# Altium schematic sheet TC-DK.SchDoc(TC-DK)
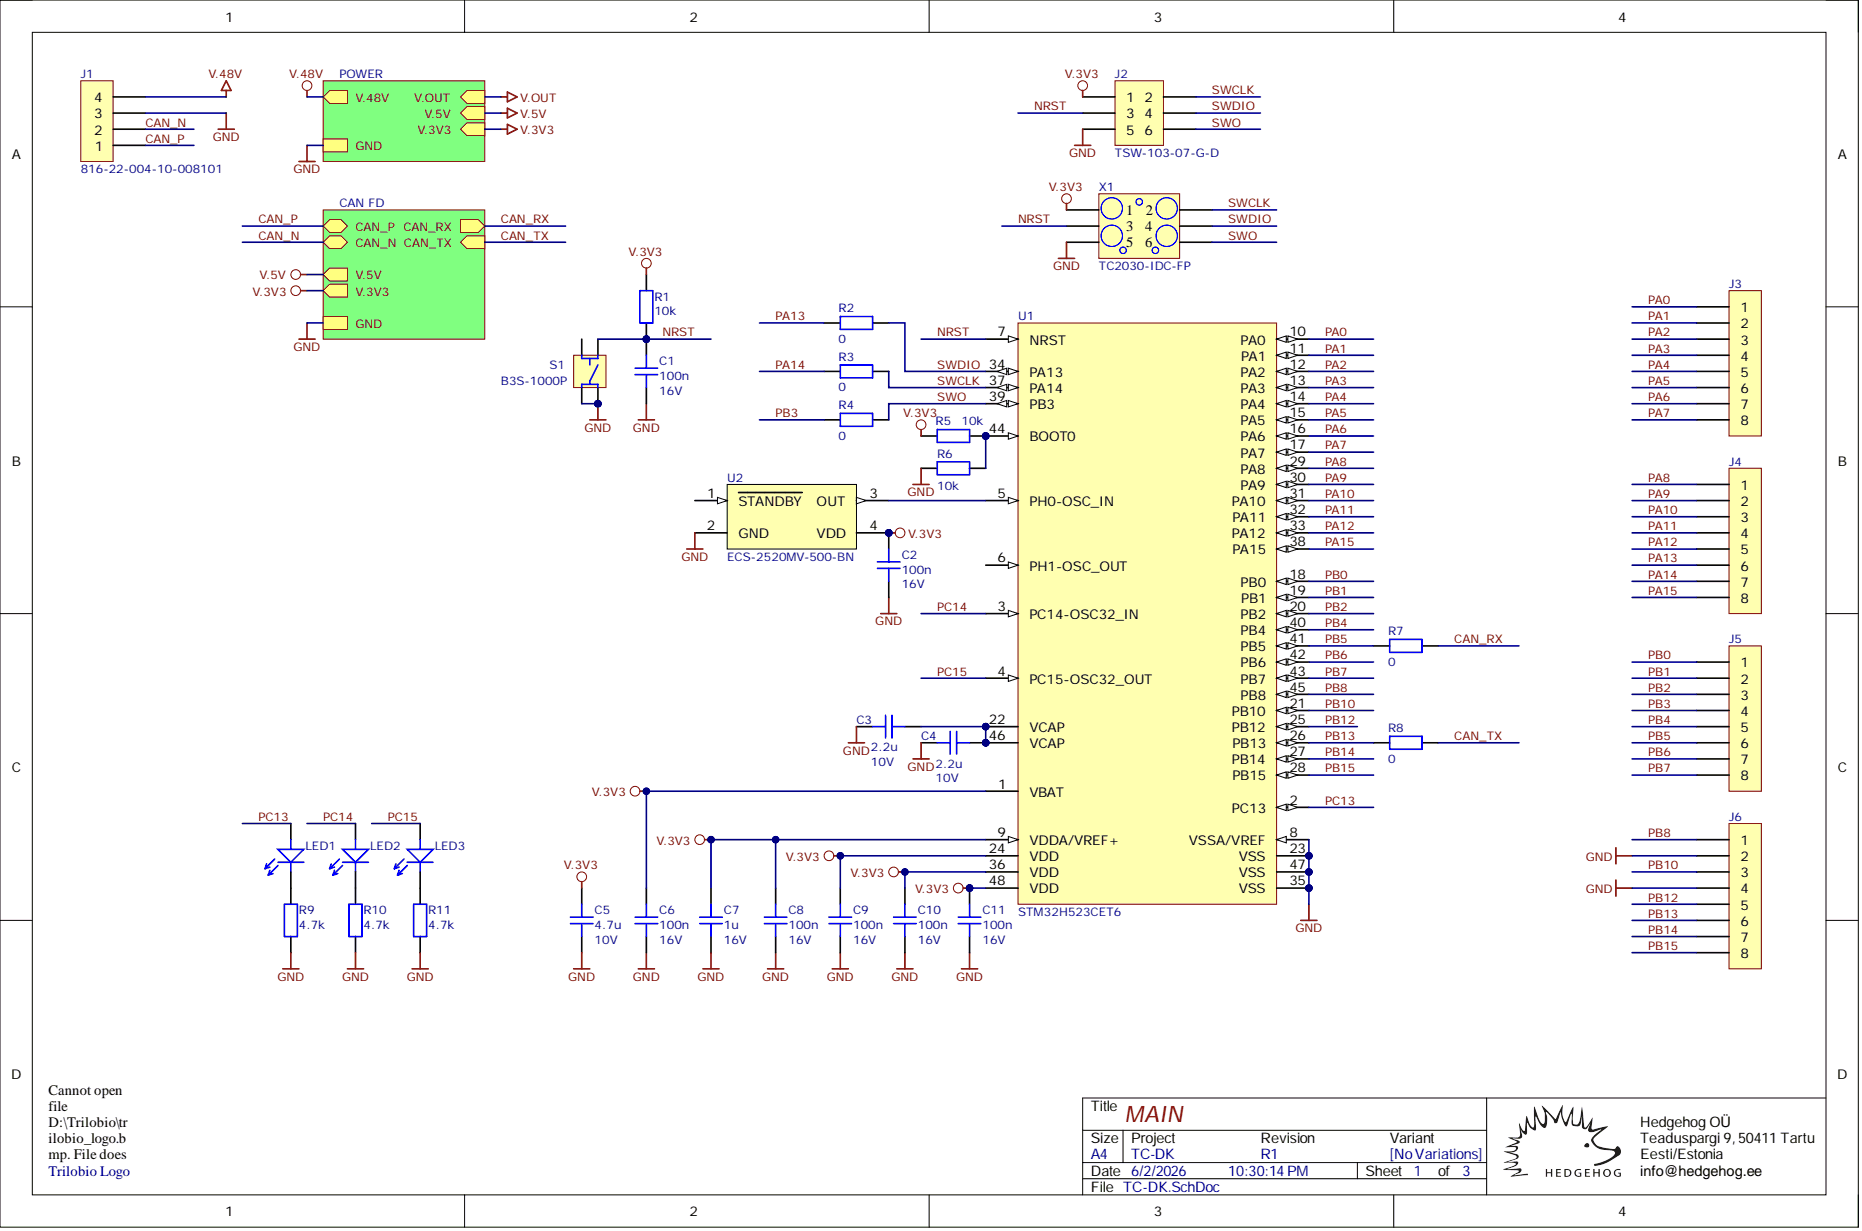
[diagram p0: TC-DK.SchDoc]

## Parts
C1  value 100n  Voltage: 16V  (hidden comment: CAPC)
C2  value 100n  Voltage: 16V  (hidden comment: CAPC)
C3  value 2.2u  Voltage: 10V  (hidden comment: CAPC)
C4  value 2.2u  Voltage: 10V  (hidden comment: CAPC)
C5  value 4.7u  Voltage: 10V  (hidden comment: CAPC)
C6  value 100n  Voltage: 16V  (hidden comment: CAPC)
C7  value 1u  Voltage: 16V  (hidden comment: CAPC)
C8  value 100n  Voltage: 16V  (hidden comment: CAPC)
C9  value 100n  Voltage: 16V  (hidden comment: CAPC)
C10  value 100n  Voltage: 16V  (hidden comment: CAPC)
C11  value 100n  Voltage: 16V  (hidden comment: CAPC)
J1  Part Number: 816-22-004-10-008101  (hidden comment: HDR1x4)
J2  Part Number: TSW-103-07-G-D  (hidden comment: HDR2x3)
J3  HDR1x8  (value not drawn)
J4  HDR1x8  (value not drawn)
J5  HDR1x8  (value not drawn)
J6  HDR1x8  (value not drawn)
LED1  DIO-LED  (value not drawn)
LED2  DIO-LED  (value not drawn)
LED3  DIO-LED  (value not drawn)
Logo1  Trilobio Logo  (no BOM)
R1  value 10k  (hidden comment: RESC)
R2  value 0  (hidden comment: RESC)
R3  value 0  (hidden comment: RESC)
R4  value 0  (hidden comment: RESC)
R5  value 10k  (hidden comment: RESC)
R6  value 10k  (hidden comment: RESC)
R7  value 0  (hidden comment: RESC)
R8  value 0  (hidden comment: RESC)
R9  value 4.7k  (hidden comment: RESC)
R10  value 4.7k  (hidden comment: RESC)
R11  value 4.7k  (hidden comment: RESC)
S1  Part Number: B3S-1000P  (hidden comment: SW_4PIN)
U1  STM32H523CET6
U2  Part Number: ECS-2520MV-500-BN  (hidden comment: XTAL2)
X1  Part Number: TC2030-IDC-FP  (hidden comment: TC2030-FP)  (no BOM)

## Nets
GND: C1.1 C2.1 C3.1 C4.1 C5.1 C6.1 C7.1 C8.1 C9.1 C10.1 C11.1 J1.3 J2.5 J6.2 J6.4 R6.1 R9.1 R10.1 R11.1 S1.3 S1.4 U1.8(VSSA/VREF) U1.23(VSS) U1.35(VSS) U1.47(VSS) U2.2(GND) X1.5
V.3V3: C2.2 C5.2 C6.2 C7.2 C8.2 C9.2 C10.2 C11.2 J2.1 R1.1 R5.1 U1.1(VBAT) U1.9(VDDA/VREF+) U1.24(VDD) U1.36(VDD) U1.48(VDD) U2.4(VDD) X1.1
V.48V: J1.4
CAN_N: J1.2
CAN_P: J1.1
CAN_RX: R7.2
CAN_TX: R8.2
NRST: C1.2 J2.3 R1.2 S1.1 U1.7(NRST) X1.3
PA0: J3.1 U1.10(PA0)
PA1: J3.2 U1.11(PA1)
PA2: J3.3 U1.12(PA2)
PA3: J3.4 U1.13(PA3)
PA4: J3.5 U1.14(PA4)
PA5: J3.6 U1.15(PA5)
PA6: J3.7 U1.16(PA6)
PA7: J3.8 U1.17(PA7)
PA8: J4.1 U1.29(PA8)
PA9: J4.2 U1.30(PA9)
PA10: J4.3 U1.31(PA10)
PA11: J4.4 U1.32(PA11)
PA12: J4.5 U1.33(PA12)
PA13: J4.6 R2.1
PA14: J4.7 R3.1
PA15: J4.8 U1.38(PA15)
PB0: J5.1 U1.18(PB0)
PB1: J5.2 U1.19(PB1)
PB2: J5.3 U1.20(PB2)
PB3: J5.4 R4.1
PB4: J5.5 U1.40(PB4)
PB5: J5.6 R7.1 U1.41(PB5)
PB6: J5.7 U1.42(PB6)
PB7: J5.8 U1.43(PB7)
PB8: J6.1 U1.45(PB8)
PB10: J6.3 U1.21(PB10)
PB12: J6.5 U1.25(PB12)
PB13: J6.6 R8.1 U1.26(PB13)
PB14: J6.7 U1.27(PB14)
PB15: J6.8 U1.28(PB15)
PC13: LED1.2 U1.2(PC13)
PC14: LED2.2 U1.3(PC14-OSC32_IN)
PC15: LED3.2 U1.4(PC15-OSC32_OUT)
SWCLK: J2.2 R3.2 U1.37(PA14) X1.2
SWDIO: J2.4 R2.2 U1.34(PA13) X1.4
SWO: J2.6 R4.2 U1.39(PB3) X1.6
NetC3_2: C3.2 C4.2 U1.22(VCAP) U1.46(VCAP)
NetLED1_1: LED1.1 R9.2
NetLED2_1: LED2.1 R10.2
NetLED3_1: LED3.1 R11.2
NetR5_2: R5.2 R6.2 U1.44(BOOT0)
NetS1_2: S1.2
NetU1_5: U1.5(PH0-OSC_IN) U2.3(OUT)
NetU1_6: U1.6(PH1-OSC_OUT)
NetU2_1: U2.1(STANDBY)
V.5V:
V.OUT:

## Library data of the parts
C1 C2 C6 C8-C11 = 20000: Ceramic chip capacitor; Manufacturer: Any; Part Number: 100n, 16V, X5R, 0402 (1005 metric) chip capacitor; Component Kind: Standard; Database Table Name: capc; Id: 20000; Package: 0402 (1005 metric) chip capacitor; Technology: X5R; Library Name: Kast.DbLib
C3 C4 = 20023: Ceramic chip capacitor; Manufacturer: Any; Part Number: 2.2u, 10V, X5R, 0603 (1608 metric) chip capacitor; Component Kind: Standard; Database Table Name: capc; Id: 20023; Package: 0603 (1608 metric) chip capacitor; Technology: X5R; Library Name: Kast.DbLib
C5 = 20084: Ceramic chip capacitor; Manufacturer: Any; Part Number: 4.7u, 10V, X5R, 0603 (1608 metric) chip capacitor; Component Kind: Standard; Database Table Name: capc; Id: 20084; Package: 0603 (1608 metric) chip capacitor; Technology: X5R; Library Name: Kast.DbLib
C7 = 20005: Ceramic chip capacitor; Manufacturer: Any; Part Number: 1u, 16V, X5R, 0603 (1608 metric) chip capacitor; Component Kind: Standard; Database Table Name: capc; Id: 20005; Package: 0603 (1608 metric) chip capacitor; Technology: X5R; Library Name: Kast.DbLib
J1 = 52531: 1X4 pin connector; Manufacturer: Mill-Max; Database Table Name: main; Value: 1x4 2.54mm pogo pin, h=10.41mm; Component Kind: Standard; HelpURL: https://mm.digikey.com/Volume0/opasdata/d220001/medias/docus/7161/54_Mill%20Max%20catalog.pdf; Id: 52531; Type: CON; Library Name: Kast.DbLib
J2 = 50793: 2X3 pin connector; Manufacturer: Samtec; Database Table Name: main; Value: 2x3 pin header; Component Kind: Standard; HelpURL: http://suddendocs.samtec.com/catalog_english/tsw_th.pdf; Id: 50793; Type: CON; Library Name: Kast.DbLib
J3-J6 = 50494: 1X8 pin connector; Manufacturer: Connfly; Part Number: DS1021-1*8SF1-1; Database Table Name: main; Value: 8 pin; Component Kind: Standard; HelpURL: http://www.tme.eu/en/Document/cc301e58a5da78cb543a94b5a5b7f06a/DS1021.pdf; Id: 50494; Type: CON; Library Name: Kast.DbLib
LED1-LED3 = 50404: LED, pin 1 cathode; Manufacturer: Liteon; Part Number: LTST-C191KGKT; Database Table Name: main; Value: Green; Component Kind: Standard; HelpURL: http://www.tme.eu/en/Document/c425f8d9d923820338ff70e5cbf9328a/LTST-C191KGKT.pdf; Id: 50404; Type: LED; Library Name: Kast.DbLib
Logo1 = CMP-011-000043-3: Description: Trilobio Logo; Library Name: Trilobio
R1 R5 R6 = 10012: Chip Resistor; Manufacturer: Any; Part Number: 10k, 1%, 62mW, 0402 (1005 metric) chip resistor; Power: 62mW; Tolerance: 1%; Component Kind: Standard; Database Table Name: resc; Id: 10012; Package: 0402 (1005 metric) chip resistor; Library Name: Kast.DbLib
R2-R4 R7 R8 = 10052: Chip Resistor; Manufacturer: Any; Part Number: 0, -, -, 0402 (1005 metric) chip resistor; Component Kind: Standard; Database Table Name: resc; Id: 10052; Package: 0402 (1005 metric) chip resistor; Power: -; Tolerance: -; Library Name: Kast.DbLib
R9-R11 = 10042: Chip Resistor; Manufacturer: Any; Part Number: 4.7k, 1%, 62mW, 0402 (1005 metric) chip resistor; Power: 62mW; Tolerance: 1%; Component Kind: Standard; Database Table Name: resc; Id: 10042; Package: 0402 (1005 metric) chip resistor; Library Name: Kast.DbLib
S1 = 50095: Switch, 4 pin; Manufacturer: Omron; Database Table Name: main; Component Kind: Standard; HelpURL: https://www.omron.com/ecb/products/pdf/en-b3s.pdf; Id: 50095; Type: SW; Library Name: Kast.DbLib
U1 = 52338: Manufacturer: ST Microelectronics; Database Table Name: main; Value: Arm Cortex M33 32-bit MCU; Component Kind: Standard; HelpURL: https://www.st.com/resource/en/datasheet/stm32h523ce.pdf; Id: 52338; Part Number: STM32H523CET6; Type: MCU; Library Name: Kast.DbLib
U2 = 52515: CMOS Oscillator; Manufacturer: ESC; Database Table Name: main; Value: 50MHz oscillator; Component Kind: Standard; HelpURL: https://ecsxtal.com/store/pdf/ECS-2520MV.pdf; Id: 52515; Type: OSC; Library Name: Kast.DbLib
X1 = 51250: TC2030-FP JTAG connector; Manufacturer: Tag-Connect; Database Table Name: main; Value: 6-pin, 1.27mm, with fingers, tag-connect; HelpURL: http://www.tag-connect.com/Materials/TC2030-IDC.pdf; Id: 51250; Type: CON; Library Name: Kast.DbLib
